# Altium schematic sheet SH1 - POWER.SchDoc
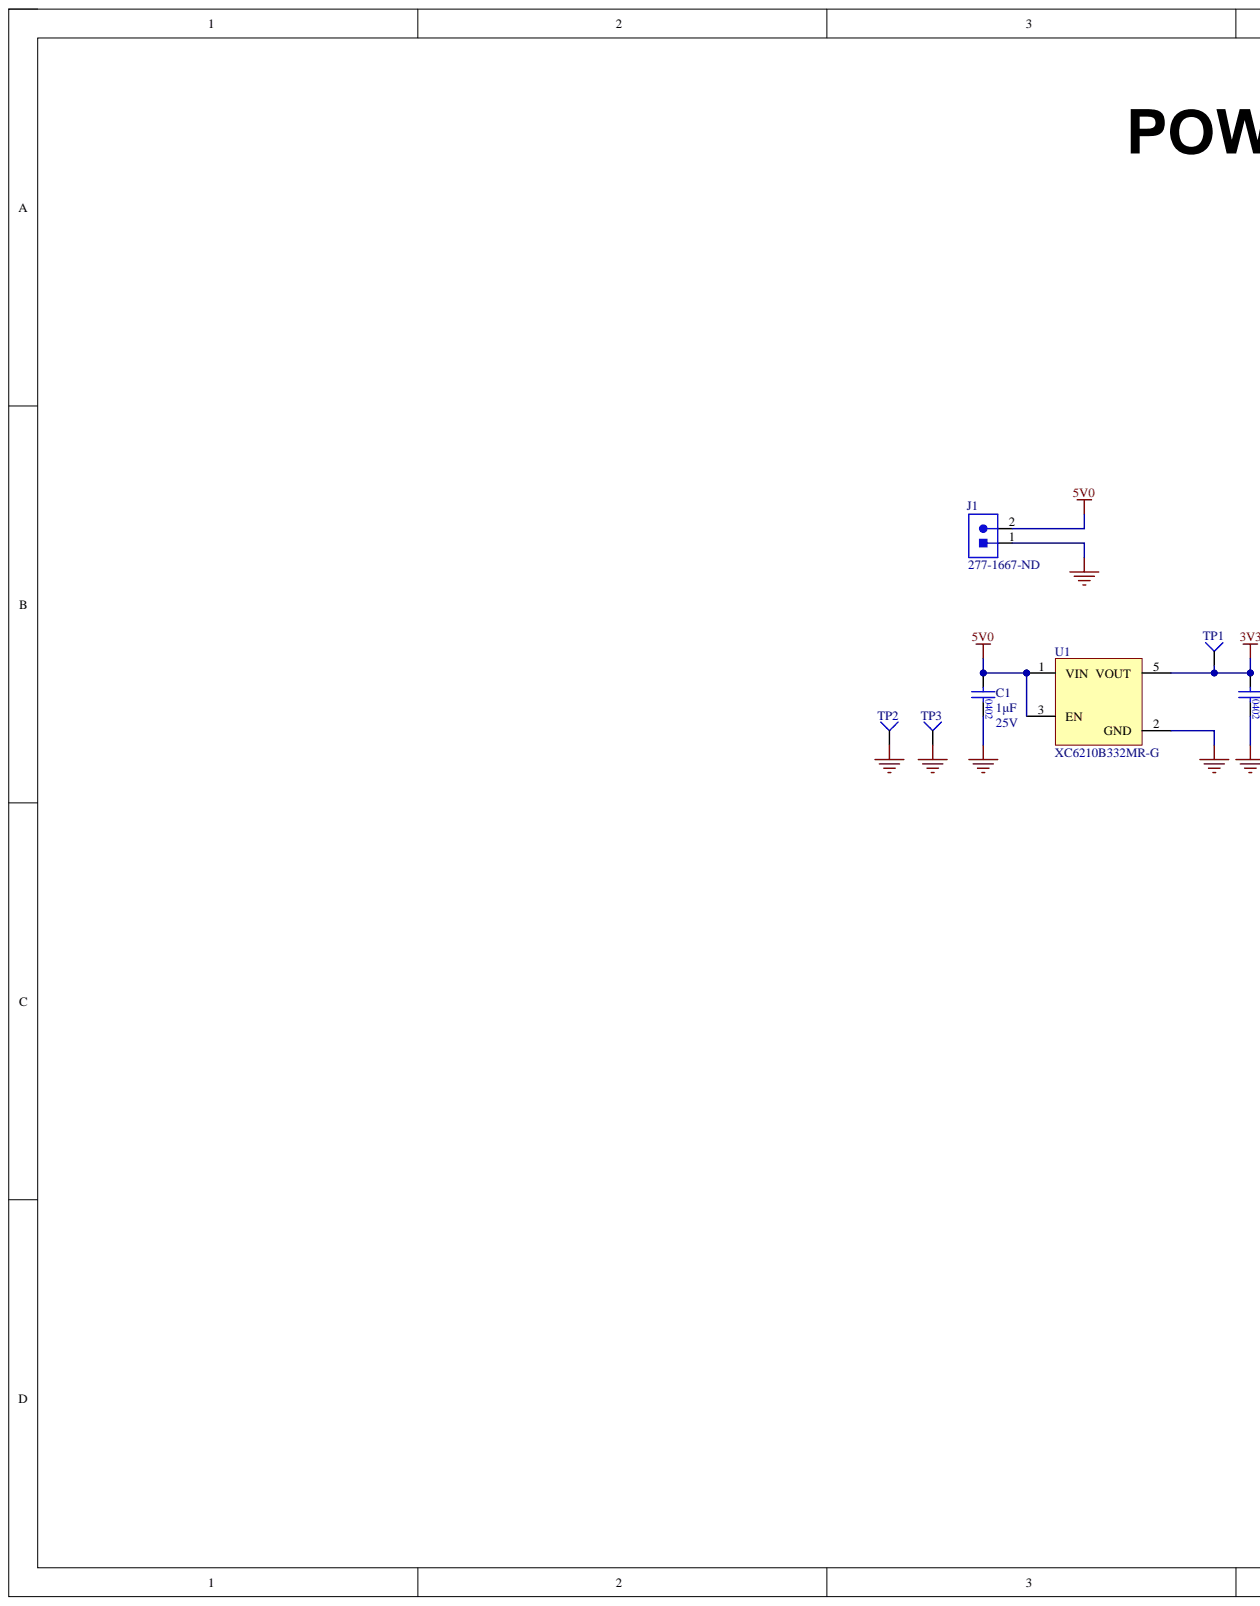
[diagram p0: SH1 - POWER.SchDoc, left]
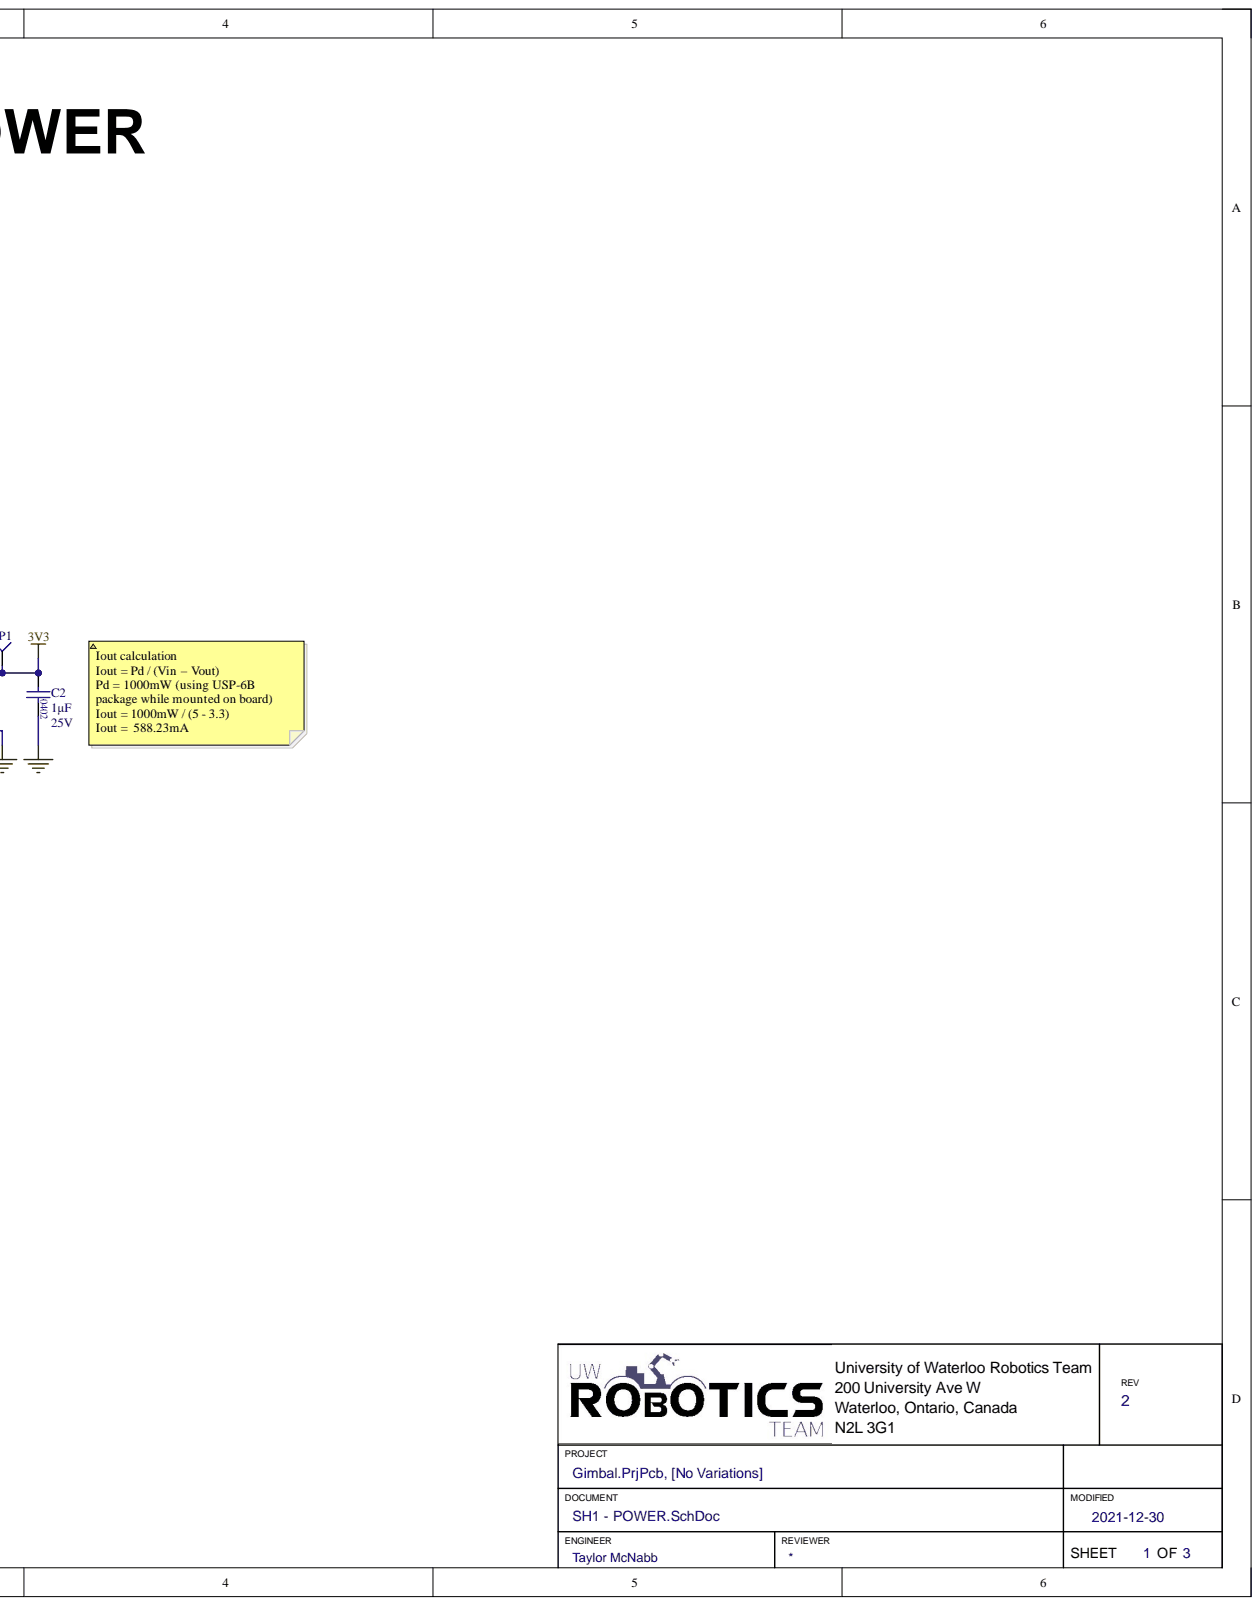
[diagram p1: SH1 - POWER.SchDoc, right]

## Parts
C1  value 1μF  Package: 0402  Voltage: 25V  [CAP0402]  (hidden comment: CAP_1uF_25V_0402)
C2  value 1μF  Package: 0402  Voltage: 25V  [CAP0402]  (hidden comment: CAP_1uF_25V_0402)
J1  Supplier Part Number 1: 277-1667-ND  [1935161]  (hidden comment: CON_2POS_SCREW_TERM)
TP1  TESTPOINT_SMD_LOOP  [S1751-46R]  (value not drawn)
TP2  TESTPOINT_SMD_LOOP  [S1751-46R]  (value not drawn)
TP3  TESTPOINT_SMD_LOOP  [S1751-46R]  (value not drawn)
U1  Manufacturer Part Number 1: XC6210B332MR-G  [SOT95P285X140-5N]  (hidden comment: LDO_3.3V_700mA)

## Nets
3V3: C2.1 TP1.1 U1.5(VOUT)
5V0: C1.1 J1.2 U1.1(VIN) U1.3(EN)
GND: C1.2 C2.2 J1.1 TP2.1 TP3.1 U1.2(GND)

## Library data of the parts
C1 C2 = CAP_1uF_25V_0402: CAP CER 1UF 25V X5R 0402; Manufacturer 1: TDK Corporation; Manufacturer Part Number 1: C1005X5R1E105K050BE; Component Kind: Standard; Supplier 1: Digi-Key; Supplier Part Number 1: 445-175215-1-ND; Library Name: UWRT_Schematic_Library_2020.SchLib
J1 = CON_2POS_SCREW_TERM: TERM BLK 2POS SIDE ENTRY 5MM; Manufacturer 1: Phoenix Contact; Package/Footprint: SMD; Component Kind: Standard; Manufacturer Part Number 1: 1935161; Mounting Type: TH; Supplier 1: Digi-Key; Library Name: UWRT_Schematic_Library_2020.SchLib
TP1-TP3 = TESTPOINT_SMD_LOOP: PC TEST POINT SMD; Manufacturer 1: Harwin; Component Kind: Standard; Manufacturer Part Number 1: S1751-46R; Mounting Type: SMD; Package/Footprint: SMD; Supplier 1: Digi-Key; Supplier Part Number 1: 952-1478-1-ND; Library Name: UWRT_Schematic_Library_2020.SchLib
U1 = LDO_3.3V_700mA: IC REG LINEAR 3.3V 700MA SOT25; Manufacturer 1: Diodes Incorporated; Input Voltage (Max): 6V; Package/Footprint: SOT-25; Voltage Dropout (Max): 0.075V; Component Kind: Standard; Mounting Type: SMD; Operating Temperature: -40°C - 85°C; Output Current: 700mA; Output Voltage (Fixed): 3.3V; Supplier 1: Digi-Key; Supplier Part Number 1: 893-1075-1-ND; Library Name: UWRT_Schematic_Library_2020.SchLib
